# Altium schematic sheet Inresol_grid_inverter_L1.SchDoc(Inresol_grid_inverter_L1)
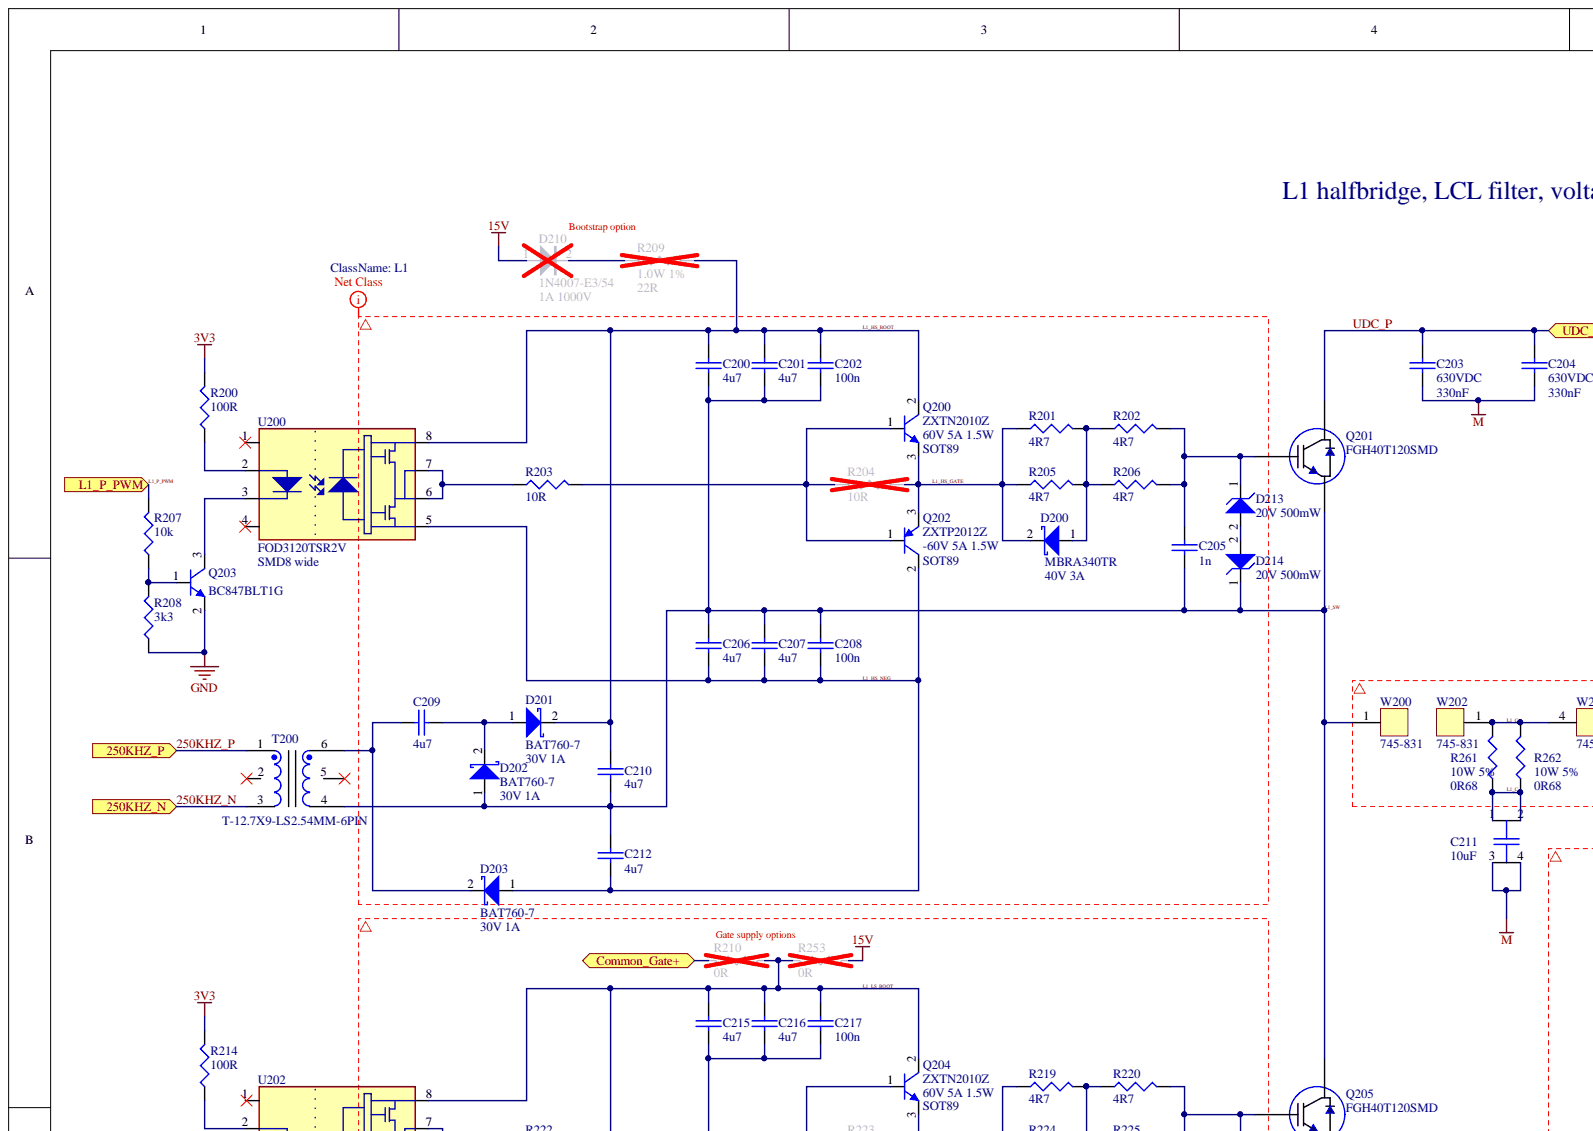
[diagram p0: Inresol_grid_inverter_L1.SchDoc, top left]
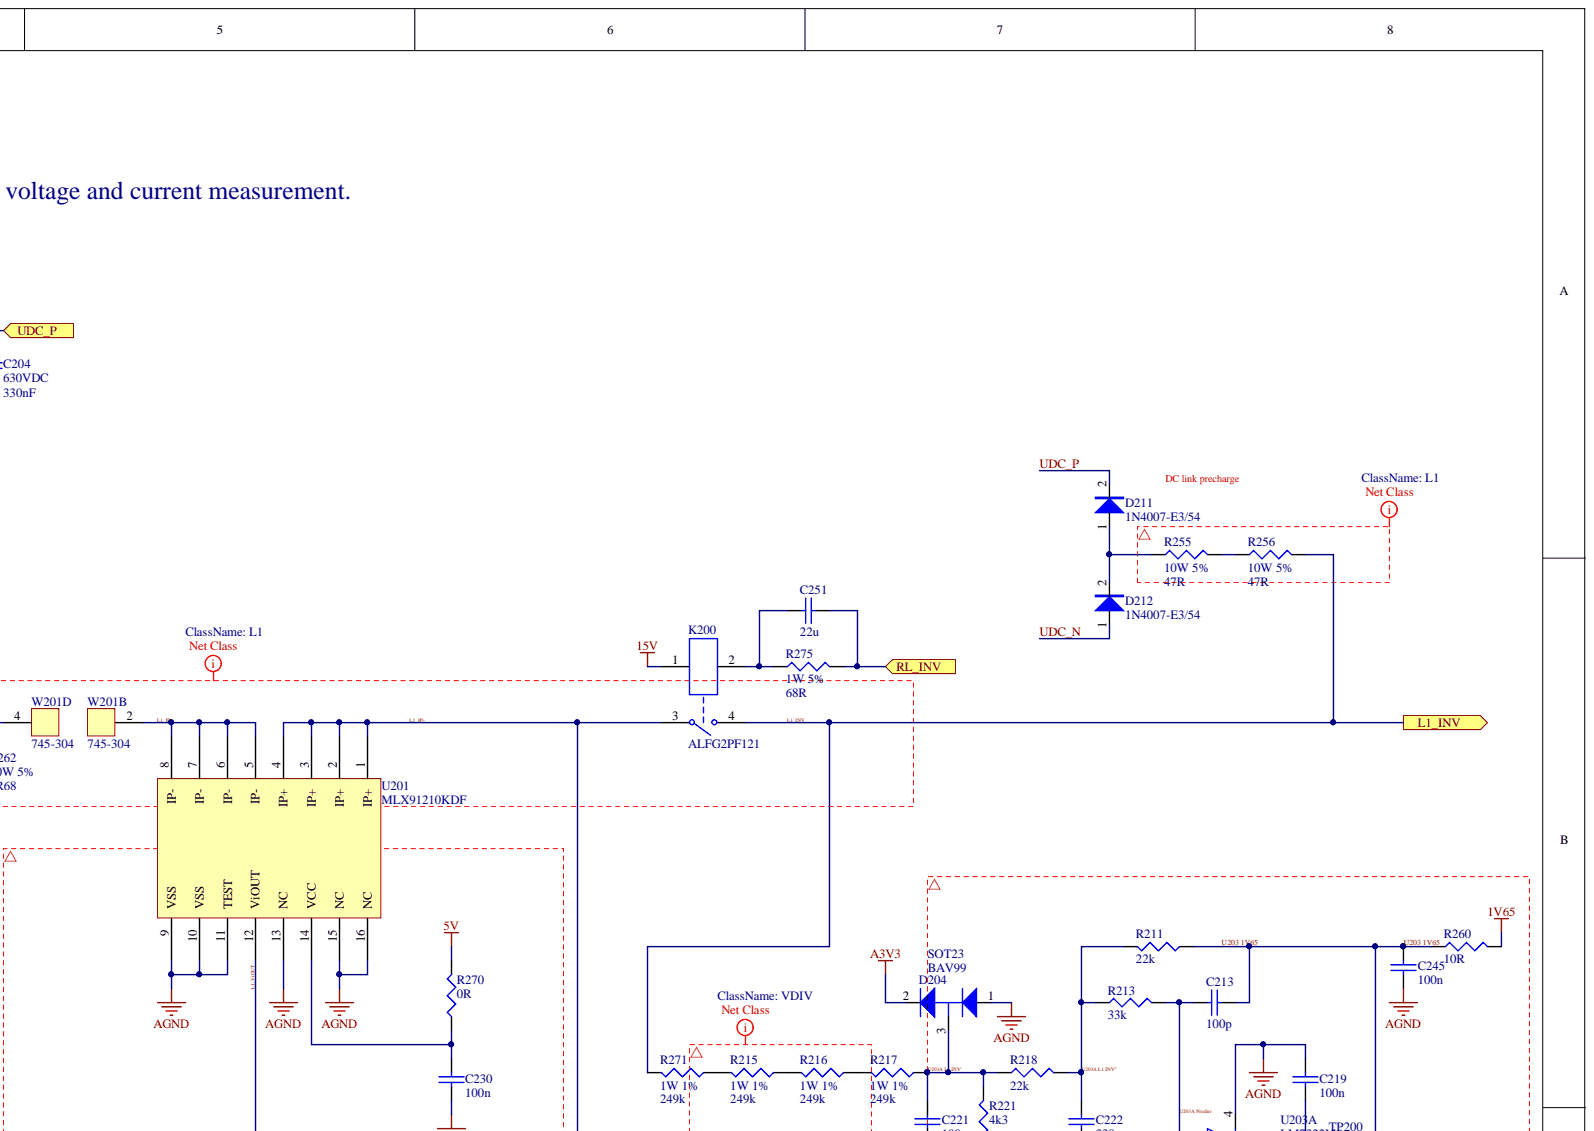
[diagram p1: Inresol_grid_inverter_L1.SchDoc, top right]
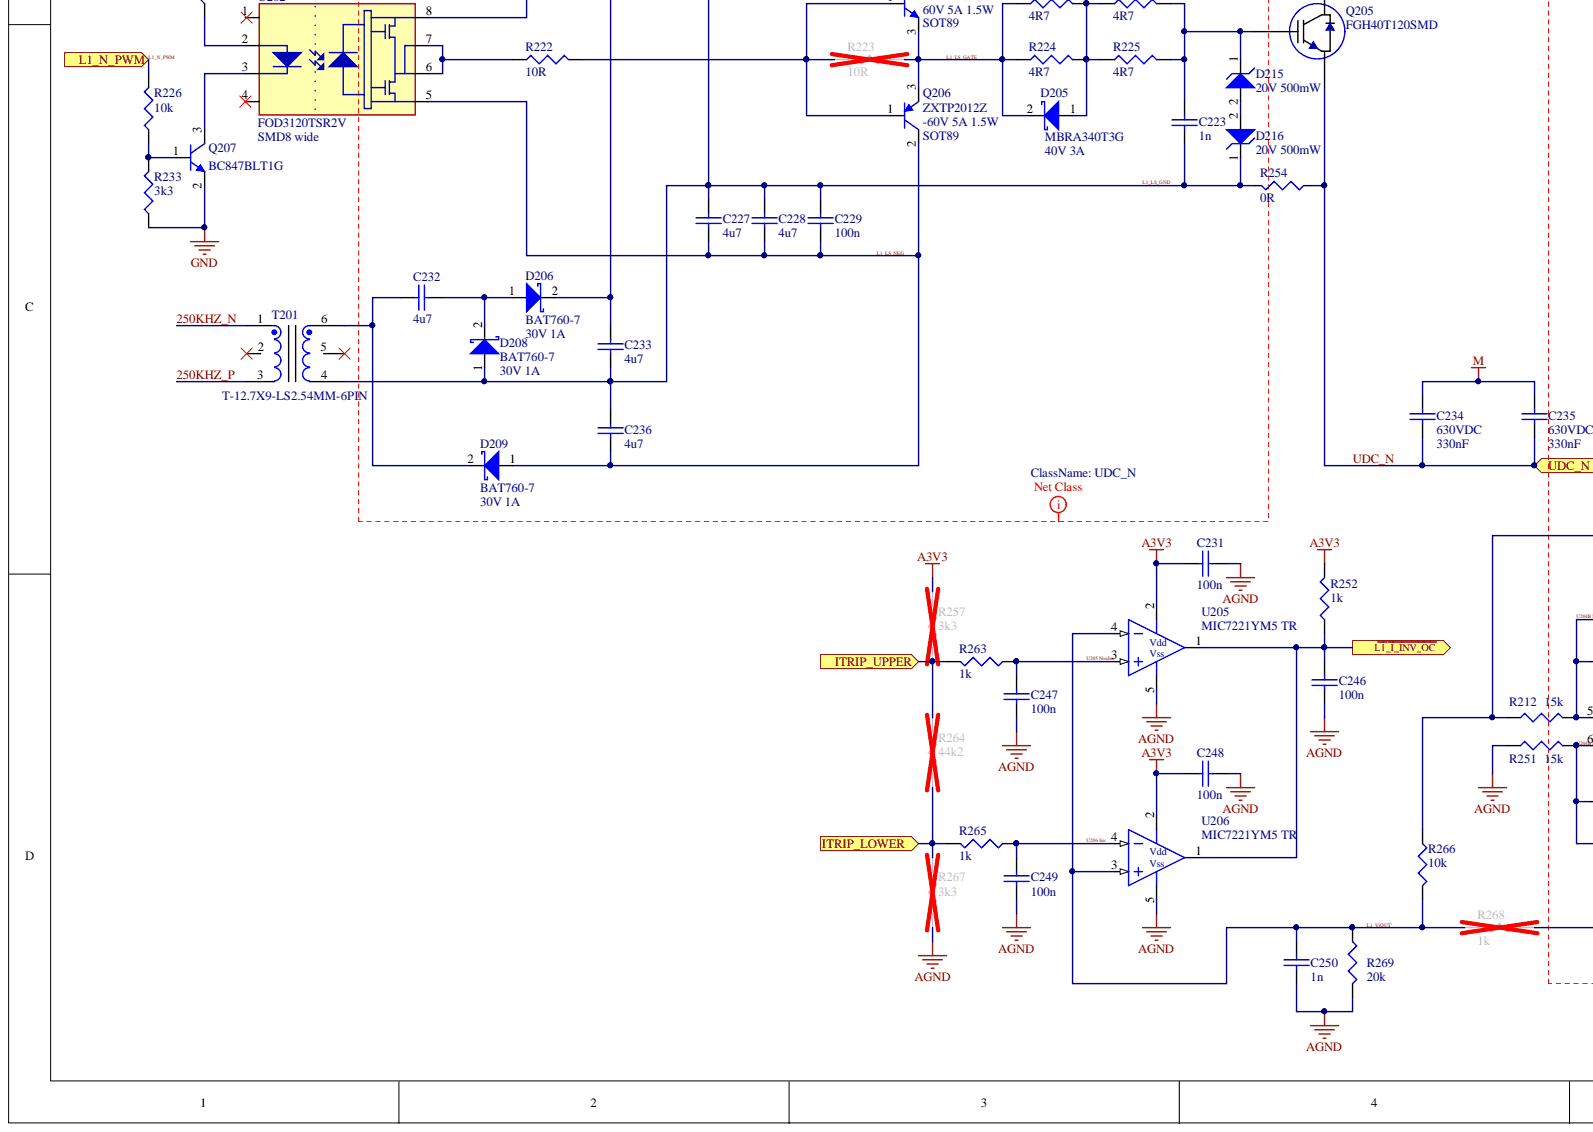
[diagram p2: Inresol_grid_inverter_L1.SchDoc, bottom left]
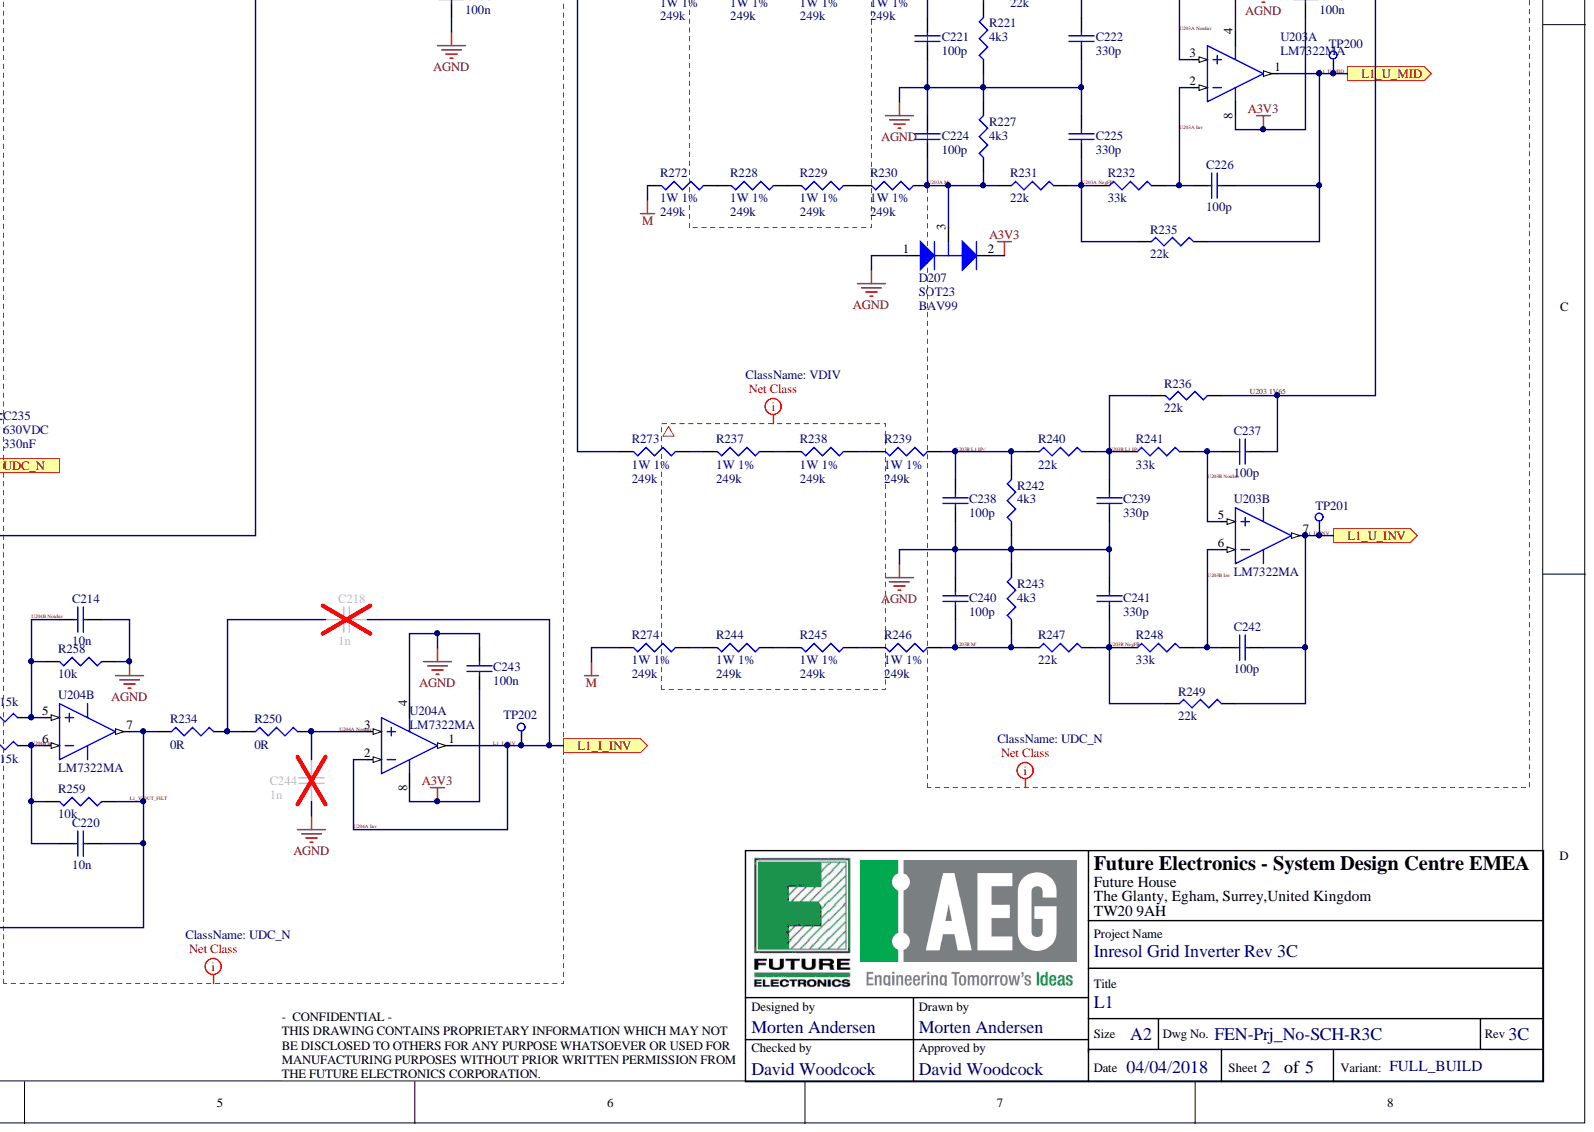
[diagram p3: Inresol_grid_inverter_L1.SchDoc, bottom right]

## Parts
C200  value 4u7  [1206]  (hidden comment: C-4.7uF-50V-Cer-1206-emea-8-10-15)
C201  value 4u7  [1206]  (hidden comment: C-4.7uF-50V-Cer-1206-emea-8-10-15)
C202  value 100n  [0603]  (hidden comment: C-100nF-50V-Cer-0603)
C203  value 330nF  Rating: 630VDC  [C-18x8.5_LS15]  (hidden comment: C-330nF-630V-PolyF-17.5x8.5)
C204  value 330nF  Rating: 630VDC  [C-18x8.5_LS15]  (hidden comment: C-330nF-630V-PolyF-17.5x8.5)
C205  value 1n  [0603]  (hidden comment: C-1nF-50V-Cer-0603)
C206  value 4u7  [1206]  (hidden comment: C-4.7uF-50V-Cer-1206-emea-8-10-15)
C207  value 4u7  [1206]  (hidden comment: C-4.7uF-50V-Cer-1206-emea-8-10-15)
C208  value 100n  [0603]  (hidden comment: C-100nF-50V-Cer-0603)
C209  value 4u7  [1206]  (hidden comment: C-4.7uF-50V-Cer-1206-emea-8-10-15)
C210  value 4u7  [1206]  (hidden comment: C-4.7uF-50V-Cer-1206-emea-8-10-15)
C211  value 10uF  (hidden comment: C-10uF-275V-PolyF-C-43x21.5)
C212  value 4u7  [1206]  (hidden comment: C-4.7uF-50V-Cer-1206-emea-8-10-15)
C213  value 100p  [0603]  (hidden comment: C-100pF-25V-Cer-0603)
C214  value 10n  [0603]  (hidden comment: C-10nF-25V-Cer-0603)
C215  value 4u7  [1206]  (hidden comment: C-4.7uF-50V-Cer-1206-emea-8-10-15)
C216  value 4u7  [1206]  (hidden comment: C-4.7uF-50V-Cer-1206-emea-8-10-15)
C217  value 100n  [0603]  (hidden comment: C-100nF-50V-Cer-0603)
C218  value 1n  [0603]  (hidden comment: C-1nF-50V-Cer-0603)
C219  value 100n  [0603]  (hidden comment: C-100nF-50V-Cer-0603)
C220  value 10n  [0603]  (hidden comment: C-10nF-25V-Cer-0603)
C221  value 100p  [0603]  (hidden comment: C-100pF-25V-Cer-0603)
C222  value 330p  [0603]  (hidden comment: C-330pF-25V-Cer-0603)
C223  value 1n  [0603]  (hidden comment: C-1nF-50V-Cer-0603)
C224  value 100p  [0603]  (hidden comment: C-100pF-25V-Cer-0603)
C225  value 330p  [0603]  (hidden comment: C-330pF-25V-Cer-0603)
C226  value 100p  [0603]  (hidden comment: C-100pF-25V-Cer-0603)
C227  value 4u7  [1206]  (hidden comment: C-4.7uF-50V-Cer-1206-emea-8-10-15)
C228  value 4u7  [1206]  (hidden comment: C-4.7uF-50V-Cer-1206-emea-8-10-15)
C229  value 100n  [0603]  (hidden comment: C-100nF-50V-Cer-0603)
C230  value 100n  [0603]  (hidden comment: C-100nF-50V-Cer-0603)
C231  value 100n  [0603]  (hidden comment: C-100nF-50V-Cer-0603)
C232  value 4u7  [1206]  (hidden comment: C-4.7uF-50V-Cer-1206-emea-8-10-15)
C233  value 4u7  [1206]  (hidden comment: C-4.7uF-50V-Cer-1206-emea-8-10-15)
C234  value 330nF  Rating: 630VDC  [C-18x8.5_LS15]  (hidden comment: C-330nF-630V-PolyF-17.5x8.5)
C235  value 330nF  Rating: 630VDC  [C-18x8.5_LS15]  (hidden comment: C-330nF-630V-PolyF-17.5x8.5)
C236  value 4u7  [1206]  (hidden comment: C-4.7uF-50V-Cer-1206-emea-8-10-15)
C237  value 100p  [0603]  (hidden comment: C-100pF-25V-Cer-0603)
C238  value 100p  [0603]  (hidden comment: C-100pF-25V-Cer-0603)
C239  value 330p  [0603]  (hidden comment: C-330pF-25V-Cer-0603)
C240  value 100p  [0603]  (hidden comment: C-100pF-25V-Cer-0603)
C241  value 330p  [0603]  (hidden comment: C-330pF-25V-Cer-0603)
C242  value 100p  [0603]  (hidden comment: C-100pF-25V-Cer-0603)
C243  value 100n  [0603]  (hidden comment: C-100nF-50V-Cer-0603)
C244  value 1n  [0603]  (hidden comment: C-1nF-50V-Cer-0603)
C245  value 100n  [0603]  (hidden comment: C-100nF-50V-Cer-0603)
C246  value 100n  [0603]  (hidden comment: C-100nF-50V-Cer-0603)
C247  value 100n  [0603]  (hidden comment: C-100nF-50V-Cer-0603)
C248  value 100n  [0603]  (hidden comment: C-100nF-50V-Cer-0603)
C249  value 100n  [0603]  (hidden comment: C-100nF-50V-Cer-0603)
C250  value 1n  [0603]  (hidden comment: C-1nF-50V-Cer-0603)
C251  value 22u  [1210]  (hidden comment: C-22uF-25V-Cer-1210)
D200  Future PN (TR): MBRA340TR  Rating: 40V 3A  [SMA]  (hidden comment: D-SMA-MBRA340T3G)
D201  MFR PN: BAT760-7  [SOD323]  (hidden comment: D-SOD323-BAT760-7)
D202  MFR PN: BAT760-7  Rating: 30V 1A  [SOD323]  (hidden comment: D-SOD323-BAT760-7)
D203  MFR PN: BAT760-7  Rating: 30V 1A  [SOD323]  (hidden comment: D-SOD323-BAT760-7)
D204  value BAV99  [SOT23]  (hidden comment: D-SOT23-BAV99)
D205  MFR PN: MBRA340T3G  Rating: 40V 3A  [SMA]  (hidden comment: D-SMA-MBRA340T3G)
D206  MFR PN: BAT760-7  [SOD323]  (hidden comment: D-SOD323-BAT760-7)
D207  value BAV99  [SOT23]  (hidden comment: D-SOT23-BAV99)
D208  MFR PN: BAT760-7  Rating: 30V 1A  [SOD323]  (hidden comment: D-SOD323-BAT760-7)
D209  MFR PN: BAT760-7  Rating: 30V 1A  [SOD323]  (hidden comment: D-SOD323-BAT760-7)
D210  MFR PN: 1N4007-E3/54  Rating: 1A 1000V  [DO-41]  (hidden comment: D-DO41-1N4007)
D211  MFR PN: 1N4007-E3/54  [DO-41]  (hidden comment: D-DO41-1N4007)
D212  MFR PN: 1N4007-E3/54  [DO-41]  (hidden comment: D-DO41-1N4007)
D213  Rating: 20V 500mW  [SOD123]  (hidden comment: D-SOD123-MMSZ5250B-20V-EMEA-19-05-16)
D214  Rating: 20V 500mW  [SOD123]  (hidden comment: D-SOD123-MMSZ5250B-20V-EMEA-19-05-16)
D215  Rating: 20V 500mW  [SOD123]  (hidden comment: D-SOD123-MMSZ5250B-20V-EMEA-19-05-16)
D216  Rating: 20V 500mW  [SOD123]  (hidden comment: D-SOD123-MMSZ5250B-20V-EMEA-19-05-16)
K200  MFR PN: ALFG2PF121  (hidden comment: K-Panasonic-Relay-SPST-NO-35A-12V-EMEA-07-09-2017)
Q200  value ZXTN2010Z  Future PN (CT): ZXTN2010Z  [SOT89]  (hidden comment: Q-SOT89-ZXTN2010Z)
Q201  MFR PN: FGH40T120SMD  [TO247]  (hidden comment: Q-TO-247-FGH40T120)
Q202  value ZXTP2012Z  MFR PN: ZXTP2012Z  [SOT89]  (hidden comment: Q-SOT89-ZXTP2012Z)
Q203  value BC847BLT1G  MFR PN: BC847BLT1G  [SOT23]  (hidden comment: Q-SOT23-BC847BLT1G)
Q204  value ZXTN2010Z  Future PN (CT): ZXTN2010Z  [SOT89]  (hidden comment: Q-SOT89-ZXTN2010Z)
Q205  MFR PN: FGH40T120SMD  [TO247]  (hidden comment: Q-TO-247-FGH40T120)
Q206  value ZXTP2012Z  MFR PN: ZXTP2012Z  [SOT89]  (hidden comment: Q-SOT89-ZXTP2012Z)
Q207  value BC847BLT1G  MFR PN: BC847BLT1G  [SOT23]  (hidden comment: Q-SOT23-BC847BLT1G)
R200  value 100R  [0603]  (hidden comment: R-100R-100mW-0603)
R201  value 4R7  [2512]  (hidden comment: R-4.7R-1W-2512)
R202  value 4R7  [2512]  (hidden comment: R-4.7R-1W-2512)
R203  value 10R  [2512]  (hidden comment: R-10R-1W-2512-EMEA-07-09-2017)
R204  value 10R  [2512]  (hidden comment: R-10R-1W-2512-EMEA-07-09-2017)
R205  value 4R7  [2512]  (hidden comment: R-4.7R-1W-2512)
R206  value 4R7  [2512]  (hidden comment: R-4.7R-1W-2512)
R207  value 10k  [0603]  (hidden comment: R-10k-100mW-0603)
R208  value 3k3  [0603]  (hidden comment: R-3.3k-100mW-0603)
R209  value 22R  Rating: 1.0W 1%  [2512]  (hidden comment: R-22R-1.0W-2512-EMEA)
R210  value 0R  [1206]  (hidden comment: R-0R-250mW-1206)
R211  value 22k  [0603]  (hidden comment: R-22k-100mW-0603)
R212  value 15k  [0603]  (hidden comment: R-15k-100mW-0603)
R213  value 33k  [0603]  (hidden comment: R-33k-100mW-0603)
R214  value 100R  [0603]  (hidden comment: R-100R-100mW-0603)
R215  value 249k  Rating: 1W 1%  [2512]  (hidden comment: R-249k-1W-2512)
R216  value 249k  Rating: 1W 1%  [2512]  (hidden comment: R-249k-1W-2512)
R217  value 249k  Rating: 1W 1%  [2512]  (hidden comment: R-249k-1W-2512)
R218  value 22k  [0603]  (hidden comment: R-22k-100mW-0603)
R219  value 4R7  [2512]  (hidden comment: R-4.7R-1W-2512)
R220  value 4R7  [2512]  (hidden comment: R-4.7R-1W-2512)
R221  value 4k3  [0603]  (hidden comment: R-4.3k-100mW-0603)
R222  value 10R  [2512]  (hidden comment: R-10R-1W-2512-EMEA-07-09-2017)
R223  value 10R  [2512]  (hidden comment: R-10R-1W-2512-EMEA-07-09-2017)
R224  value 4R7  [2512]  (hidden comment: R-4.7R-1W-2512)
R225  value 4R7  [2512]  (hidden comment: R-4.7R-1W-2512)
R226  value 10k  [0603]  (hidden comment: R-10k-100mW-0603)
R227  value 4k3  [0603]  (hidden comment: R-4.3k-100mW-0603)
R228  value 249k  Rating: 1W 1%  [2512]  (hidden comment: R-249k-1W-2512)
R229  value 249k  Rating: 1W 1%  [2512]  (hidden comment: R-249k-1W-2512)
R230  value 249k  Rating: 1W 1%  [2512]  (hidden comment: R-249k-1W-2512)
R231  value 22k  [0603]  (hidden comment: R-22k-100mW-0603)
R232  value 33k  [0603]  (hidden comment: R-33k-100mW-0603)
R233  value 3k3  [0603]  (hidden comment: R-3.3k-100mW-0603)
R234  value 0R  [0603]  (hidden comment: R-0R-100mW-0603)
R235  value 22k  [0603]  (hidden comment: R-22k-100mW-0603)
R236  value 22k  [0603]  (hidden comment: R-22k-100mW-0603)
R237  value 249k  Rating: 1W 1%  [2512]  (hidden comment: R-249k-1W-2512)
R238  value 249k  Rating: 1W 1%  [2512]  (hidden comment: R-249k-1W-2512)
R239  value 249k  Rating: 1W 1%  [2512]  (hidden comment: R-249k-1W-2512)
R240  value 22k  [0603]  (hidden comment: R-22k-100mW-0603)
R241  value 33k  [0603]  (hidden comment: R-33k-100mW-0603)
R242  value 4k3  [0603]  (hidden comment: R-4.3k-100mW-0603)
R243  value 4k3  [0603]  (hidden comment: R-4.3k-100mW-0603)
R244  value 249k  Rating: 1W 1%  [2512]  (hidden comment: R-249k-1W-2512)
R245  value 249k  Rating: 1W 1%  [2512]  (hidden comment: R-249k-1W-2512)
R246  value 249k  Rating: 1W 1%  [2512]  (hidden comment: R-249k-1W-2512)
R247  value 22k  [0603]  (hidden comment: R-22k-100mW-0603)
R248  value 33k  [0603]  (hidden comment: R-33k-100mW-0603)
R249  value 22k  [0603]  (hidden comment: R-22k-100mW-0603)
R250  value 0R  [0603]  (hidden comment: R-0R-100mW-0603)
R251  value 15k  [0603]  (hidden comment: R-15k-100mW-0603)
R252  value 1k  [0603]  (hidden comment: R-1k-100mW-0603)
R253  value 0R  [1206]  (hidden comment: R-0R-250mW-1206)
R254  value 0R  [1206]  (hidden comment: R-0R-250mW-1206)
R255  value 47R  Rating: 10W 5%  [13x9]  (hidden comment: R-47R-10W-13x9x51-EMEA-19-01-2016)
R256  value 47R  Rating: 10W 5%  [13x9]  (hidden comment: R-47R-10W-13x9x51-EMEA-19-01-2016)
R257  value 3k3  [0603]  (hidden comment: R-3.3k-100mW-0603)
R258  value 10k  [0603]  (hidden comment: R-10k-100mW-0603)
R259  value 10k  [0603]  (hidden comment: R-10k-100mW-0603)
R260  value 10R  [0603]  (hidden comment: R-10R-100mW-0603)
R261  value 0R68  Rating: 10W 5%  [13x9]  (hidden comment: R-0R68-10W-13x9x51-EMEA-07-09-2017)
R262  value 0R68  Rating: 10W 5%  [13x9]  (hidden comment: R-0R68-10W-13x9x51-EMEA-07-09-2017)
R263  value 1k  [0603]  (hidden comment: R-1k-100mW-0603)
R264  value 44k2  [0603]  (hidden comment: R-44.2k-100mW -0603)
R265  value 1k  [0603]  (hidden comment: R-1k-100mW-0603)
R266  value 10k  [0603]  (hidden comment: R-10k-100mW-0603)
R267  value 3k3  [0603]  (hidden comment: R-3.3k-100mW-0603)
R268  value 1k  [0603]  (hidden comment: R-1k-100mW-0603)
R269  value 20k  [0603]  (hidden comment: R-20k-100mW-0603)
R270  value 0R  [0603]  (hidden comment: R-0R-100mW-0603)
R271  value 249k  Rating: 1W 1%  [2512]  (hidden comment: R-249k-1W-2512)
R272  value 249k  Rating: 1W 1%  [2512]  (hidden comment: R-249k-1W-2512)
R273  value 249k  Rating: 1W 1%  [2512]  (hidden comment: R-249k-1W-2512)
R274  value 249k  Rating: 1W 1%  [2512]  (hidden comment: R-249k-1W-2512)
R275  value 68R  Rating: 1W 5%  [2512]  (hidden comment: R-68R-1W-2512)
T200  Custom  [T-12.7X9-LS2.54MM-6PIN]  (value not drawn)
T201  Custom  [T-12.7X9-LS2.54MM-6PIN]  (value not drawn)
TP200  TP-TEST POINT-LOOP WT-EMEA-15-10-15  [SMD test point round]  (value not drawn)  (no BOM)
TP201  TP-TEST POINT-LOOP WT-EMEA-15-10-15  [SMD test point round]  (value not drawn)  (no BOM)
TP202  TP-TEST POINT-LOOP WT-EMEA-15-10-15  [SMD test point round]  (value not drawn)  (no BOM)
U200  value FOD3120TSR2V  MFR PN: FOD3120TSR2V  [SMD8 wide]  (hidden comment: U-SMD8 wide -FOD3120SD)
U201  Rating: MLX91210KDF  [SOIC16W]  (hidden comment: U- SOICW 16PIN-MLX91210KDF-EMEA-24-08-2017)
U202  value FOD3120TSR2V  MFR PN: FOD3120TSR2V  [SMD8 wide]  (hidden comment: U-SMD8 wide -FOD3120SD)
U203  value LM7322MA  MFR PN: LM7322MA  [SO8]  (hidden comment: U-SOIC8-LM7322MA-EMEA)  (parts A,B)
U204  value LM7322MA  MFR PN: LM7322MA  [SO8]  (hidden comment: U-SOIC8-LM7322MA-EMEA)  (parts A,B)
U205  U-SOT23_5-MIC7221YM5 TR  [SOT23_5]  (value not drawn)
U206  U-SOT23_5-MIC7221YM5 TR  [SOT23_5]  (value not drawn)
W200  J-WAGO_745-831  [745-831]  (value not drawn)
W201  J-WAGO_745-304_blocks  [745-304]  (value not drawn)  (parts B,D)
W202  J-WAGO_745-831  [745-831]  (value not drawn)

## Ports
250KHZ_N, 250KHZ_P, COMMON_GATE+, ITRIP_LOWER, ITRIP_UPPER, L1_I_INV, L1_INV, L1_N_PWM, L1_P_PWM, L1_U_INV, L1_U_MID, RL_INV, UDC_N, UDC_P, ~{L1_I_INV_OC}

## Nets
1V65: R260.2
3V3: R200.2 R214.2
5V: R270.2
15V: D210.1 K200.1 R253.1
A3V3: C219.2 C231.1 C243.2 C248.1 D204.2 D207.2 R252.2 R257.2 U203.8 U204.8 U205.2 U206.2
AGND: C214.2 C219.1 C221.2 C222.2 C224.1 C225.1 C230.2 C231.2 C238.2 C239.2 C240.1 C241.1 C243.1 C244.2 C245.2 C246.1 C247.1 C248.2 C249.1 C250.1 D204.1 D207.1 R221.1 R227.2 R242.1 R243.2 R251.1 R258.1 R267.1 R269.2 U201.9(VSS) U201.10(VSS) U201.11(TEST) U201.13(NC) U201.15(NC) U201.16(NC) U203.4 U204.4 U205.5 U206.5
COMMON_GATE+: R210.2 ; port COMMON_GATE+
GND: Q203.2 Q207.2 R208.1 R233.1
M: C203.2 C204.2 C211.3 C211.4 C234.2 C235.2 R272.2 R274.2
250KHZ_N: T200.3 T201.1 ; port 250KHZ_N
250KHZ_P: T200.1 T201.3 ; port 250KHZ_P
ITRIP_LOWER: R264.1 R265.2 R267.2 ; port ITRIP_LOWER
ITRIP_UPPER: R257.1 R263.2 R264.2 ; port ITRIP_UPPER
L1_C: R261.2 R262.2 W201.4 W202.1
L1_C_: C211.1 C211.2 R261.1 R262.1
L1_HS_BOOT: C200.2 C201.2 C202.1 C210.2 D201.2 Q200.2 R209.2 U200.8
L1_HS_GATE: D200.2 Q200.3 Q202.3 R201.1 R204.2 R205.1
L1_HS_NEG: C206.1 C207.1 C208.2 C212.1 D203.1 Q202.2 U200.5
L1_I_INV: C218.2 TP202.1 U204.1 U204.2 ; port L1_I_INV
L1_INV: K200.4 R256.2 R271.2 ; port L1_INV
L1_IP+: K200.3 R273.2 U201.1(IP+) U201.2(IP+) U201.3(IP+) U201.4(IP+)
L1_IP-: U201.5(IP-) U201.6(IP-) U201.7(IP-) U201.8(IP-) W201.2
L1_LS_BOOT: C215.2 C216.2 C217.1 C233.2 D206.2 Q204.2 R210.1 R253.2 U202.8
L1_LS_GATE: D205.2 Q204.3 Q206.3 R219.1 R223.2 R224.1
L1_LS_GND: C215.1 C216.1 C217.2 C223.2 C227.2 C228.2 C229.1 C233.1 C236.2 D208.1 D216.1 R254.2 T201.4
L1_LS_NEG: C227.1 C228.1 C229.2 C236.1 D209.1 Q206.2 U202.5
L1_N_PWM: R226.2 ; port L1_N_PWM
L1_P_PWM: R207.2 ; port L1_P_PWM
L1_SW: C200.1 C201.1 C202.2 C205.2 C206.2 C207.2 C208.1 C210.1 C212.2 D202.1 D214.1 Q201.3 Q205.2 T200.4 W200.1
L1_U_INV: C242.1 R249.2 TP201.1 U203.7 ; port L1_U_INV
L1_U_MID: C226.1 R235.2 TP200.1 U203.1 ; port L1_U_MID
L1_VIOUT: R212.1 R266.1 U201.12(ViOUT)
L1_VIOUT': C250.2 R266.2 R268.1 R269.1 U205.4 U206.3
L1_VIOUT_FILT: C220.2 R234.1 R259.1 R268.2 U204.7
~{L1_I_INV_OC}: C246.2 R252.1 U205.1 U206.1 ; port ~{L1_I_INV_OC}
RL_INV: C251.2 R275.2 ; port RL_INV
U203 1V65: C213.1 C237.1 C245.1 R211.1 R236.1 R260.1
U203A INV: C226.2 R232.2 U203.2
U203A L1 INV': C221.1 D204.3 R217.1 R218.1 R221.2
U203A L1 INV'': C222.1 R211.2 R213.1 R218.2
U203A M': C224.2 D207.3 R227.1 R230.1 R231.1
U203A NEGFB: C225.2 R231.2 R232.1 R235.1
U203A NONINV: C213.2 R213.2 U203.3
U203B INV: C242.2 R248.2 U203.6
U203B L1 IP+': C238.1 R239.1 R240.1 R242.2
U203B L1 IP+'': C239.1 R236.2 R240.2 R241.1
U203B M': C240.2 R243.1 R246.1 R247.1
U203B NEGFB: C241.2 R247.2 R248.1 R249.1
U203B NONINV: C237.2 R241.2 U203.5
U204A NONINV: C244.1 R250.2 U204.3
U204B INV: C220.1 R251.2 R259.2 U204.6
U204B NONINV: C214.1 R212.2 R258.2 U204.5
U205 NONINV: C247.2 R263.1 U205.3
U206 INV: C249.2 R265.1 U206.4
UDC_N: C234.1 C235.1 D212.1 Q205.3 R254.1 ; port UDC_N
UDC_P: C203.1 C204.1 D211.2 Q201.2 ; port UDC_P
NetC205_1: C205.1 D213.1 Q201.1 R202.2 R206.2
NetC209_1: C209.1 D203.2 T200.6
NetC209_2: C209.2 D201.1 D202.2
NetC218_1: C218.1 R234.2 R250.1
NetC223_1: C223.1 D215.1 Q205.1 R220.2 R225.2
NetC230_1: C230.1 R270.1 U201.14(VCC)
NetC232_1: C232.1 D209.2 T201.6
NetC232_2: C232.2 D206.1 D208.2
NetC251_1: C251.1 K200.2 R275.1
NetD200_1: D200.1 R201.2 R202.1 R205.2 R206.1
NetD205_1: D205.1 R219.2 R220.1 R224.2 R225.1
NetD210_2: D210.2 R209.1
NetD211_1: D211.1 D212.2 R255.1
NetD213_2: D213.2 D214.2
NetD215_2: D215.2 D216.2
NetQ200_1: Q200.1 Q202.1 R203.2 R204.1
NetQ203_1: Q203.1 R207.1 R208.2
NetQ203_3: Q203.3 U200.3
NetQ204_1: Q204.1 Q206.1 R222.2 R223.1
NetQ207_1: Q207.1 R226.1 R233.2
NetQ207_3: Q207.3 U202.3
NetR200_1: R200.1 U200.2
NetR203_1: R203.1 U200.6 U200.7
NetR214_1: R214.1 U202.2
NetR215_1: R215.1 R216.2
NetR215_2: R215.2 R271.1
NetR216_1: R216.1 R217.2
NetR222_1: R222.1 U202.6 U202.7
NetR228_1: R228.1 R229.2
NetR228_2: R228.2 R272.1
NetR229_1: R229.1 R230.2
NetR237_1: R237.1 R238.2
NetR237_2: R237.2 R273.1
NetR238_1: R238.1 R239.2
NetR244_1: R244.1 R245.2
NetR244_2: R244.2 R274.1
NetR245_1: R245.1 R246.2
NetR255_2: R255.2 R256.1
N00180:
N00181:
N00182:
N00183:
N00184:
N00185:
N00186:
N00187:

Not connected: T200 2 5; T201 2 5; U200 1 4; U202 1 4

## Library data of the parts
C200 C201 C206 C207 C209 C210 C212 C215 C216 C227 C228 C232 C233 C236 = C-4.7uF-50V-Cer-1206-emea-8-10-15: Cap 1206 SM X7R 50V 10%; MFR: SAMSUNG; MFR PN: CL31B475KBHNNNE; Apr'd: raised emea 8th Oct 2015; Future PN (CT): TBA; Future PN (TR): 12065C475KATM; Rating: 50V 10%; Component Kind: Standard; ComponentLink1Description: Datasheet; ComponentLink1URL: http://www.samsungsem.com/servlet/ProductSearchView?page=1&searchIdx=1&lang=kr&partnum=1&find_val=CL31B475KBHNNNE; Library Name: EMEA CAPACITORS_FEB2016.SchLib
C202 C208 C217 C219 C229-C231 C243 C245-C249 = C-100nF-50V-Cer-0603: Cap 0603 SM X7R 50V 10%; MFR: AVX; MFR PN: 06035C104K4T2A; Future PN (CT): 06035C104K4TN-CT; Future PN (TR): 06035C104K4TN; Rating: 50V 10%; Component Kind: Standard; ComponentLink1Description: Datasheet; ComponentLink1URL: http://www.avx.com/docs/Catalogs/cx7r.pdf; Library Name: EMEA CAPACITORS_FEB2016.SchLib; Verified: AD030615
C203 C204 C234 C235 = C-330nF-630V-PolyF-17.5x8.5-EMEA-07-09-2017: Cap 17.5x8.5 TH Polyprop 630VDC; MFR: VISHAY; MFR PN: BFC233820334; Apr'd: TBD; Future PN (CT): TBA; Component Kind: Standard; ComponentLink1Description: Datasheet; ComponentLink1URL: https://www.vishay.com/docs/28119/mkp3382.pdf; Future PN (TR): TBD; Library Name: Inresol_grid_inverter.SchLib
C205 C218 C223 C244 C250 = C-1nF-50V-Cer-0603: Cap 0603 SM X7R 50V 10%; MFR: VISHAY; MFR PN: VJ0603Y102KXACW1BC; Future PN (CT): 06035C102KATN-CT; Future PN (TR): 06035C102KATN; Rating: 50V 10%; Component Kind: Standard; ComponentLink1Description: Datasheet; ComponentLink1URL: http://www.vishay.com/docs/28548/vjw1bcbascomseries.pdf; Library Name: Future Capacitors.SCHLIB; Verified: AD030615
C211 = C-10uF-275V-PolyF-C-43x21.5: Cap 43x21.5 TH Polyprop 275V 5%; MFR: Vishay; MFR PN: MKP1847610274P4; Future PN (CT): TBD; Rating: 275Vac 5%; Component Kind: Standard; ComponentLink1Description: Datasheet; ComponentLink1URL: http://www.vishay.com/docs/28172/mkp1847ac.pdf; Future PN (TR): TBD; Library Name: Inresol_grid_inverter.SchLib
C213 C221 C224 C226 C237 C238 C240 C242 = C-100pF-25V-Cer-0603: Cap 0603 SM X7R 25V 10%; MFR: AVX; MFR PN: 06033C101KAT2A; Future PN (CT): 06033C101KATN-CT; Future PN (TR): 06033C101KATN; Rating: 25V 10%; Component Kind: Standard; ComponentLink1Description: Datasheet; ComponentLink1URL: http://www.avx.com/docs/Catalogs/cx7r.pdf; Library Name: Future Capacitors.SCHLIB; Verified: AD030615
C214 C220 = C-10nF-25V-Cer-0603: Cap 0603 SM X7R 25V 10%; MFR: AVX; MFR PN: 06033C103KAT2A; Future PN (CT): 06033C103KATN-CT; Future PN (TR): 06033C103KATN; Rating: 25V 10%; Component Kind: Standard; ComponentLink1Description: Datasheet; ComponentLink1URL: http://www.avx.com/docs/Catalogs/cx7r.pdf; Library Name: Future Capacitors.SCHLIB; Verified: AD030615
C222 C225 C239 C241 = C-330pF-25V-Cer-0603: Cap 0603 SM X7R 25V 1%; MFR: AVX; MFR PN: 06033A331FAT2A; Future PN (CT): TBD; Future PN (TR): 06033A331FATN; Rating: 25V 1%; Component Kind: Standard; ComponentLink1Description: Datasheet; ComponentLink1URL: http://www.avx.com/docs/Catalogs/ccog.pdf; Library Name: Future Capacitors.SCHLIB; Verified: AD030615
C251 = C-22uF-25V-Cer-1210: Cap 1210 SM X7R 25V 10%; MFR: MURATA; MFR PN: GRM32ER71E226KE15L; Future PN (CT): TBD; Future PN (TR): 12103C226KATM; Rating: 25V 10%; Component Kind: Standard; ComponentLink1Description: Datasheet; ComponentLink1URL: http://search.murata.co.jp/Ceramy/image/img/A01X/partnumbering_e_01.pdf; Library Name: Future Capacitors.SCHLIB; Verified: AD030615
D200 = D-SMA-MBRA340T3G: Diode Schottky 40V 3A SMD; MFR: On Semi; Apr'd: YES; Future PN (CT): MBRA340TR-CT; Component Kind: Standard; ComponentLink1Description: Datasheet; ComponentLink1URL: http://www.onsemi.com/pub_link/Collateral/MBRA340T3-D.PDF; Library Name: EMEA SEMICONDUCTORS_FEB2016.SchLib; MFR PN: MBRA340T3G
D201 D206 = D-SOD323-BAT760-7: Diode Schottky 30V 1A SMD; MFR: DIO; Future PN (CT): TBD; Rating: 30V 1A; Component Kind: Standard; ComponentLink1Description: Datasheet; ComponentLink1URL: https://www.diodes.com/assets/Datasheets/ds30498.pdf; Future PN (TR): TBD; Library Name: Inresol_grid_inverter.SchLib
D202 D203 D208 D209 = D-SOD323-BAT760-7: Diode Schottky 30V 1A SMD; MFR: DIO; Future PN (CT): TBD; Component Kind: Standard; ComponentLink1Description: Datasheet; ComponentLink1URL: https://www.diodes.com/assets/Datasheets/ds30498.pdf; Future PN (TR): TBD; Library Name: Inresol_grid_inverter.SchLib
D204 D207 = D-SOT23-BAV99: Diode, Silicon Dual connected in series SOT23; MFR: DIO; Future PN (CT): BAV99LT1-CT; Future PN (TR): BAV99-7-F; Rating: 75V 300mA; Component Kind: Standard; ComponentLink1Description: Datasheet; ComponentLink1URL: http://www.diodes.com/datasheets/ds12007.pdf; Library Name: Future Semiconductors.SCHLIB; MFR PN: BAV99-7-F
D205 = D-SMA-MBRA340T3G: Diode Schottky 40V 3A SMD; MFR: On Semi; Apr'd: YES; Future PN (CT): MBRA340TR-CT; Future PN (TR): MBRA340TR; Component Kind: Standard; ComponentLink1Description: Datasheet; ComponentLink1URL: http://www.onsemi.com/pub_link/Collateral/MBRA340T3-D.PDF; Library Name: EMEA SEMICONDUCTORS_FEB2016.SchLib
D210-D212 = D-DO41-1N4007: Diode Switching Si 1A 1000V; MFR: VISHAY; Apr'd: YES; Future PN (CT): 1N4007TR-CT; Future PN (TR): 1N4007TR; Component Kind: Standard; ComponentLink1Description: Datasheet; ComponentLink1URL: http://www.vishay.com/docs/88503/1n4001.pdf; Library Name: Future Semiconductors.SCHLIB
  D211 D212: Rating: 1A 1000V
D213-D216 = D-SOD123-MMSZ5250B-20V-EMEA-19-05-16: Diode Zener 20V 500mW; MFR: DIODES INC; Apr'd: RAISED EMEA 19-05-16; Future PN (CT): TBD; Component Kind: Standard; ComponentLink1Description: Datasheet; ComponentLink1URL: http://www.farnell.com/datasheets/1670964.pdf; Future PN (TR): TBD; Library Name: EMEA SEMICONDUCTORS_FEB2016.SchLib; MFR PN: MMSZ5250B; Signal Integrity: D Zener; Simulation: ZENER; Value: MMSZ5250B
K200 = K-Panasonic-Relay-SPST-NO-35A-12V-EMEA-07-09-2017: K-Relay, SPST-NO 35A 12VDC; Future PN (CT): TBD; Rating: SPST-NO 12VDC, 250VAC 31A; Component Kind: Standard; ComponentLink1Description: Datasheet; ComponentLink1URL: http://www3.panasonic.biz/ac/e/search_num/index.jsp?c=detail&part_no=ALFG2PF121; Future PN (TR): TBD; MFR: Panasonic; Simulation: SPSTRELAY; Library Name: Inresol_grid_inverter.SchLib
Q200 Q204 = Q-SOT89-ZXTN2010Z: Transistor NPN, 60V 5A 1.5W SOT89-EMEA29-09-2016; MFR: DIODES; Apr'd: YES; Future PN (TR): TBD; Component Kind: Standard; ComponentLink1Description: Datasheet; ComponentLink1URL: http://www.diodes.com/_files/datasheets/ZXTN2010Z.pdf; MFR PN: ZXTN2010Z; Rating: 60V 5A 1.5W; Library Name: Inresol_grid_inverter.SchLib
Q201 Q205 = Q-TO-247-FGH40T120: TRENCH IGBT-FGH40T120-EMEA28-09-2016; MFR: Fairchild; Apr'd: RAISED EMEA 20TH SEPT 2016; Future PN (CT): TBA; Rating: 1200V; Value: 40A; Component Kind: Standard; ComponentLink1Description: Datasheet; ComponentLink1URL: https://www.fairchildsemi.com/datasheets/FG/FGH40T120SMD.pdf; Future PN (TR): TBA; Library Name: Inresol_grid_inverter.SchLib
Q202 Q206 = Q-SOT89-ZXTP2012Z: Transistor PNP, -60V -5A 1.5W SOT89-EMEA29-09-2016; MFR: DIODES; Apr'd: YES; Future PN (CT): TBD; Rating: -60V 5A 1.5W; Component Kind: Standard; ComponentLink1Description: Datasheet; ComponentLink1URL: http://www.diodes.com/_files/datasheets/ZXTP2012Z.pdf; Future PN (TR): TBD; Library Name: Inresol_grid_inverter.SchLib
Q203 Q207 = Q-SOT23-BC847BLT1G: Transistor NPN SW, 45V 100mA SOT23; MFR: ON SEMI; Apr'd: YES; Future PN (CT): BC847BLT1-CT; Component Kind: Standard; ComponentLink1Description: Datasheet; ComponentLink1URL: http://www.onsemi.com/pub_link/Collateral/BC846ALT1-D.PDF; Future PN (TR): BC847BLT1; Library Name: Future Semiconductors.SCHLIB; Rating: 45V 100mA; Signal Integrity: MMBT2222A; Simulation: QMMBT2222A
R200 R214 = R-100R-100mW-0603: Res 0603 SM 100mW 1% 100ppm; MFR: YAGEO; MFR PN: RC0603FR-07100RL; Future PN (CT): CR0603-1000FTR-CT; Future PN (TR): CR0603-1000FTR; Component Kind: Standard; ComponentLink1Description: Datasheet; ComponentLink1URL: http://www.yageo.com/documents/recent/PYu-RC0603_51_RoHS_L_4.pdf; Library Name: Future Resistors.SCHLIB; Rating: 100mW 1%
R201 R202 R205 R206 R219 R220 R224 R225 = R-4.7R-1W-2512: Res 2512 SM 5W 1% 200ppm; MFR: STACKPOLE; MFR PN: RPC2512JT4R70; Future PN (CT): TBD; Rating: 1W 5%; Component Kind: Standard; ComponentLink1Description: Datasheet; ComponentLink1URL: http://www.yageo.com/documents/recent/PYu-RC1206_51_RoHS_L_4.pdf; Future PN (TR): TBD; Library Name: Future Resistors.SCHLIB
R203 R204 R222 R223 = R-10R-1W-2512-EMEA-07-09-2017: Res 2512 SM 1W 5%; MFR: YAGEO; MFR PN: RC2512JK-0710RL; Future PN (CT): TBD; Rating: 1W 1%; Component Kind: Standard; ComponentLink1Description: Datasheet; ComponentLink1URL: http://www.yageo.com/documents/recent/PYu-RC2512_51_RoHS_L_4.pdf; Future PN (TR): TBD; Library Name: Inresol_grid_inverter.SchLib
R207 R226 R258 R259 R266 = R-10k-100mW-0603: Res 0603 SM 100mW 1% 100ppm; MFR: YAGEO; MFR PN: RC0603FR-0710KL; Future PN (CT): CR0603-1002FTR-CT; Future PN (TR): CR0603-1002FTR; Component Kind: Standard; ComponentLink1Description: Datasheet; ComponentLink1URL: http://www.yageo.com/documents/recent/PYu-RC0603_51_RoHS_L_4.pdf; Library Name: Future Resistors.SCHLIB; Rating: 100mW 1%
R208 R233 R257 R267 = R-3.3k-100mW-0603: Res 0603 SM 100mW 1% 100ppm; MFR: YAGEO; MFR PN: RC0603FR-073K3L; Future PN (CT): CR0603-3301FTR-CT; Future PN (TR): CR0603-3301FTR; Component Kind: Standard; ComponentLink1Description: Datasheet; ComponentLink1URL: http://www.yageo.com/documents/recent/PYu-RC0603_51_RoHS_L_4.pdf; Library Name: Future Resistors.SCHLIB; Rating: 100mW 1%
R209 = R-22R-1.0W-2512-EMEA: Res 2512 SM 1.0W 1% 100ppm; MFR: VISHAY; MFR PN: CRCW251222R0FKEG; Apr'd: RAISED EMEA 4TH FEB 2013; Future PN (CT): TBD; Future PN (TR): CR2512-22R0FTR; Component Kind: Standard; ComponentLink1Description: Datasheet; ComponentLink1URL: http://www.vishay.com/docs/20043/crcwhpe3.pdf; Library Name: EMEA RESISTORS_FEB2016.SchLib; Signal Integrity: Res; Simulation: RESISTOR
R210 R253 R254 = R-0R-250mW-1206: Res 1206 SM 250mW; MFR: YAGEO; MFR PN: RC1206JR-070RL; Future PN (CT): CR1206-0000JTR-CT; Future PN (TR): CR1206-0000JTR; Component Kind: Standard; ComponentLink1Description: Datasheet; ComponentLink1URL: http://www.yageo.com/documents/recent/PYu-RC1206_51_RoHS_L_4.pdf; Library Name: Future Resistors.SCHLIB; Rating: 250mW
R211 R218 R231 R235 R236 R240 R247 R249 = R-22k-100mW-0603: Res 0603 SM 100mW 1% 100ppm; MFR: YAGEO; MFR PN: RC0603FR-0722KL; Future PN (CT): CR0603-2202FTR-CT; Future PN (TR): CR0603-2202FTR; Component Kind: Standard; ComponentLink1Description: Datasheet; ComponentLink1URL: http://www.yageo.com/documents/recent/PYu-RC0603_51_RoHS_L_4.pdf; Library Name: Future Resistors.SCHLIB; Rating: 100mW 1%
R212 R251 = R-15k-100mW-0603: Res 0603 SM 100mW 1% 100ppm; MFR: YAGEO; MFR PN: RC0603FR-0715KL; Future PN (CT): CR0603-1502FTR-CT; Future PN (TR): CR0603-1502FTR; Component Kind: Standard; ComponentLink1Description: Datasheet; ComponentLink1URL: http://www.yageo.com/pdf/yageo/Yu-RC0603_51_PbFree_L_2.pdf; Library Name: Future Resistors.SCHLIB; Rating: 100mW 1%
R213 R232 R241 R248 = R-33k-100mW-0603: Res 0603 SM 100mW 1% 100ppm; MFR: YAGEO; MFR PN: RC0603FR-0733KL; Future PN (CT): CR0603-3302FTR-CT; Future PN (TR): CR0603-3302FTR; Component Kind: Standard; ComponentLink1Description: Datasheet; ComponentLink1URL: http://www.yageo.com/documents/recent/PYu-RC0603_51_RoHS_L_4.pdf; Library Name: Future Resistors.SCHLIB; Rating: 100mW 1%
R215-R217 R228-R230 R237-R239 R244-R246 R271-R274 = R-249k-1W-2512-EMEA-25-08-2017: Res 2512 249k SM 1W 1%; MFR: Vishay; MFR PN: CRCW2512249KFKEG; Future PN (CT): TBD; Component Kind: Standard; ComponentLink1Description: Datasheet; ComponentLink1URL: http://www.vishay.com/doc?20035; Future PN (TR): TBD; Library Name: Inresol_grid_inverter.SchLib
R221 R227 R242 R243 = R-4.3k-100mW-0603: Res 0603 SM 100mW 1% 100ppm; MFR: YAGEO; MFR PN: RC0603FR-074K3L; Future PN (CT): CR0603-4301FTR-CT; Future PN (TR): CR0603-4301FTR; Component Kind: Standard; ComponentLink1Description: Datasheet; ComponentLink1URL: http://www.yageo.com/pdf/yageo/Yu-RC0603_51_PbFree_L_2.pdf; Library Name: Future Resistors.SCHLIB; Rating: 100mW 1%
R234 R250 R270 = R-0R-100mW-0603: Res 0603 SM 100mW; MFR: YAGEO; MFR PN: RC0603JR-070RL; Future PN (CT): CR0603-0000JTR-R-CT; Future PN (TR): CR0603-0000JTR-R; Component Kind: Standard; ComponentLink1Description: Datasheet; ComponentLink1URL: http://www.rohm.com/products/databook/r/pdf/mcr03.pdf; Library Name: Future Resistors.SCHLIB; Rating: 100mW
R252 R263 R265 R268 = R-1k-100mW-0603: Res 0603 SM 100mW 1% 100ppm; MFR: YAGEO; MFR PN: RC0603FR-071KL; Future PN (CT): CR0603-1001FTR-CT; Future PN (TR): CR0603-1001FTR; Component Kind: Standard; ComponentLink1Description: Datasheet; ComponentLink1URL: http://www.yageo.com/documents/recent/PYu-RC0603_51_RoHS_L_4.pdf; Library Name: EMEA RESISTORS_FEB2016.SchLib; Rating: 100mW 1%
R255 R256 = R-47R-10W-13x9x51-EMEA-19-01-2016: Res 13x9x51 TH 10W 5% WW; MFR: TE; MFR PN: SQMW1047RJ; Future PN (CT): TBD; Component Kind: Standard; ComponentLink1Description: Datasheet; ComponentLink1URL: http://www.te.com/usa-en/product-1623785-4.html; Future PN (TR): TBD; Library Name: Inresol_grid_inverter.SchLib
R260 = R-10R-100mW-0603: Res 0603 SM 100mW 1% 100ppm; MFR: YAGEO; MFR PN: RC0603FR-0710RL; Future PN (CT): CR0603-10R0FTR-CT; Future PN (TR): CR0603-10R0FTR; Component Kind: Standard; ComponentLink1Description: Datasheet; ComponentLink1URL: http://www.yageo.com/documents/recent/PYu-RC0603_51_RoHS_L_4.pdf; Library Name: Future Resistors.SCHLIB; Rating: 100mW 1%
R261 R262 = R-0R68-10W-13x9x51-EMEA-07-09-2017: Res 13x9x51 TH 10W 5% WW; MFR: TE; MFR PN: SQMW10R68J; Future PN (CT): TBD; Component Kind: Standard; ComponentLink1Description: Datasheet; ComponentLink1URL: http://www.te.com/usa-en/product-1623785-4.html; Future PN (TR): TBD; Library Name: Inresol_grid_inverter.SchLib
R264 = R-44.2k-100mW -0603: Res 0603 SM 100mW 1% 100ppm; MFR: VISHAY; MFR PN: CRCW060344K2FKEA; Future PN (CT): CR0603-4422FTR-CT; Future PN (TR): CR0603-4422FTR; Component Kind: Standard; ComponentLink1Description: Datasheet; ComponentLink1URL: http://www.vishay.com/docs/20035/dcrcwe3.pdf; Library Name: Future Resistors.SCHLIB; Rating: 100mW 1%
R269 = R-20k-100mW-0603: Res 0603 SM 100mW 1% 100ppm; MFR: YAGEO; MFR PN: RC0603FR-0720KL; Future PN (CT): CR0603-2002FTR-CT; Future PN (TR): CR0603-2002FTR; Component Kind: Standard; ComponentLink1Description: Datasheet; ComponentLink1URL: http://www.yageo.com/documents/recent/PYu-RC0603_51_RoHS_L_4.pdf; Library Name: Future Resistors.SCHLIB; Rating: 100mW 1%
R275 = R-68R-1W-2512-EMEA-20-12-2017: Res 2512 68R SM 1W 5%; MFR: YAGEO; MFR PN: RC2512JK-0768RL; Future PN (CT): TBD; Component Kind: Standard; ComponentLink1Description: Datasheet; ComponentLink1URL: http://www.futureelectronics.com/en/Search.aspx?dsNav=Ntk:PartNumberSearch%7CRC2512JK%2F-0768RL%7C1%7C,Ny:True,Ro:0,Nea:True; Future PN (TR): TBD; Library Name: Inresol_grid_inverter.SchLib
T200 T201 = T-Signal Transformer-78601/8JC: Custom Signal Transformer; MFR: Murata; Future PN (CT): TBD; Component Kind: Standard; ComponentLink1Description: Datasheet; ComponentLink1URL: http://www.murata-ps.com/datasheet?http://www.murata-ps.com/data/magnetics/kmp_786j.pdf; Future PN (TR): TBD; MFR PN: 78601/8JC; Library Name: Inresol_grid_inverter.SchLib
TP200-TP202 = TP-TEST POINT-LOOP WT-EMEA-15-10-15: Test Point; MFR: NA; Future PN (CT): NONE; Future PN (TR): TBD; ComponentLink1Description: Datasheet; Library Name: EMEA MISC_FEB2016.SchLib; MFR PN: NA; Signal Integrity: Connector
U200 U202 = U-SMD8 wide -FOD3120SD: IC, High Noise Immunity, 2.5 A Output Current,   Gate Drive Optocoupler, wideSMD8-EMEA29-09-2016; MFR: FAIRCHILD; Future PN (CT): TBD; Component Kind: Standard; ComponentLink1Description: Datasheet; ComponentLink1URL: https://www.fairchildsemi.com/datasheets/FO/FOD3120.pdf; Future PN (TR): TBD; Library Name: Inresol_grid_inverter.SchLib
U201 = U- SOICW 16PIN-MLX91210KDF-EMEA-24-08-2017: MLX91210KDF ISOLATED CURRENT SENSOR; MFR: Melexis; MFR PN: MLX91210KDF-CAS-102-RE; APPR'D: Raised EMEA 21/09/2016; ComponentLink1 Description: *Datasheet; Future PN (CT): *tba; Future PN (TR): tba; Component Kind: Standard; Componentlink1 URL: https://www.melexis.com/-/media/files/documents/datasheets/mlx91210-datasheet-melexis.pdf; Library Name: Inresol_grid_inverter.SchLib
U203 U204 = U-SOIC8-LM7322MA-EMEA_20122017: IC, Gen Purpose Dual OpAmp LM7322MA; MFR: TI; Future PN (TR): NA; Rating: 5V; Component Kind: Standard; ComponentLink1Description: Datasheet; ComponentLink1URL: http://uk.farnell.com/fairchild-semiconductor/lmv358am8x/ic-op-amp-1-4mhz-1-5v-us-soic-8/dp/1262552; Library Name: Inresol_grid_inverter.SchLib
U205 U206 = U-SOT23_5-MIC7221YM5 TR: IC, Rail to Rail Input Comparator wt Open-Drain Output; MFR: MICREL; Apr'd: YES; Future PN (CT): MIC7221BM5TR-CT; Future PN (TR): MIC7221BM5TR; Rating: 2-10V; Component Kind: Standard; ComponentLink1Description: Datasheet; ComponentLink1URL: http://www.micrel.com/_PDF/mic7211.pdf; Library Name: Future Semiconductors.SCHLIB; MFR PN: MIC7221YM5 TR
W200 W202 = J-WAGO_745-831: Connector WAGO 4 pin; Future PN (CT): TBD; Component Kind: Standard; ComponentLink1Description: Datasheet; ComponentLink1URL: http://www.wago.us/search/index.jsp?_ts=1479964580288&action=noresults&q=745-831&nav=top%2Fproductpath%3Asearch_productpath_label%C7%81f%2Fsearch_productpath_label%2F00005_pcb+terminal+blocks+and+connectors%2F00001_pcb+terminal+blocks%2F00007_pin+spacing+7.5+%26%2347%3B+7.62+mm%C7%81search_productpath_label%C7%8100007_Pin+spacing+7.5+%26%2347%3B+7.62+mm; Future PN (TR): TBD; MFR: WAGO; MFR PN: 745-831; Signal Integrity: Connector; Value: WAGO; Library Name: Inresol_grid_inverter.SchLib
W201 = J-WAGO_745-304_blocks: Connector WAGO 4 pin; Future PN (CT): TBD; Component Kind: Standard; ComponentLink1Description: Datasheet; ComponentLink1URL: http://www.wago.us/search/index.jsp?_ts=1479484242100&action=morearticledownloads&q=745-304; Future PN (TR): TBD; MFR: WAGO; MFR PN: 745-304; Signal Integrity: Connector; Value: WAGO; Library Name: Inresol_grid_inverter.SchLib
